# Altium schematic sheet Relays.SchDoc
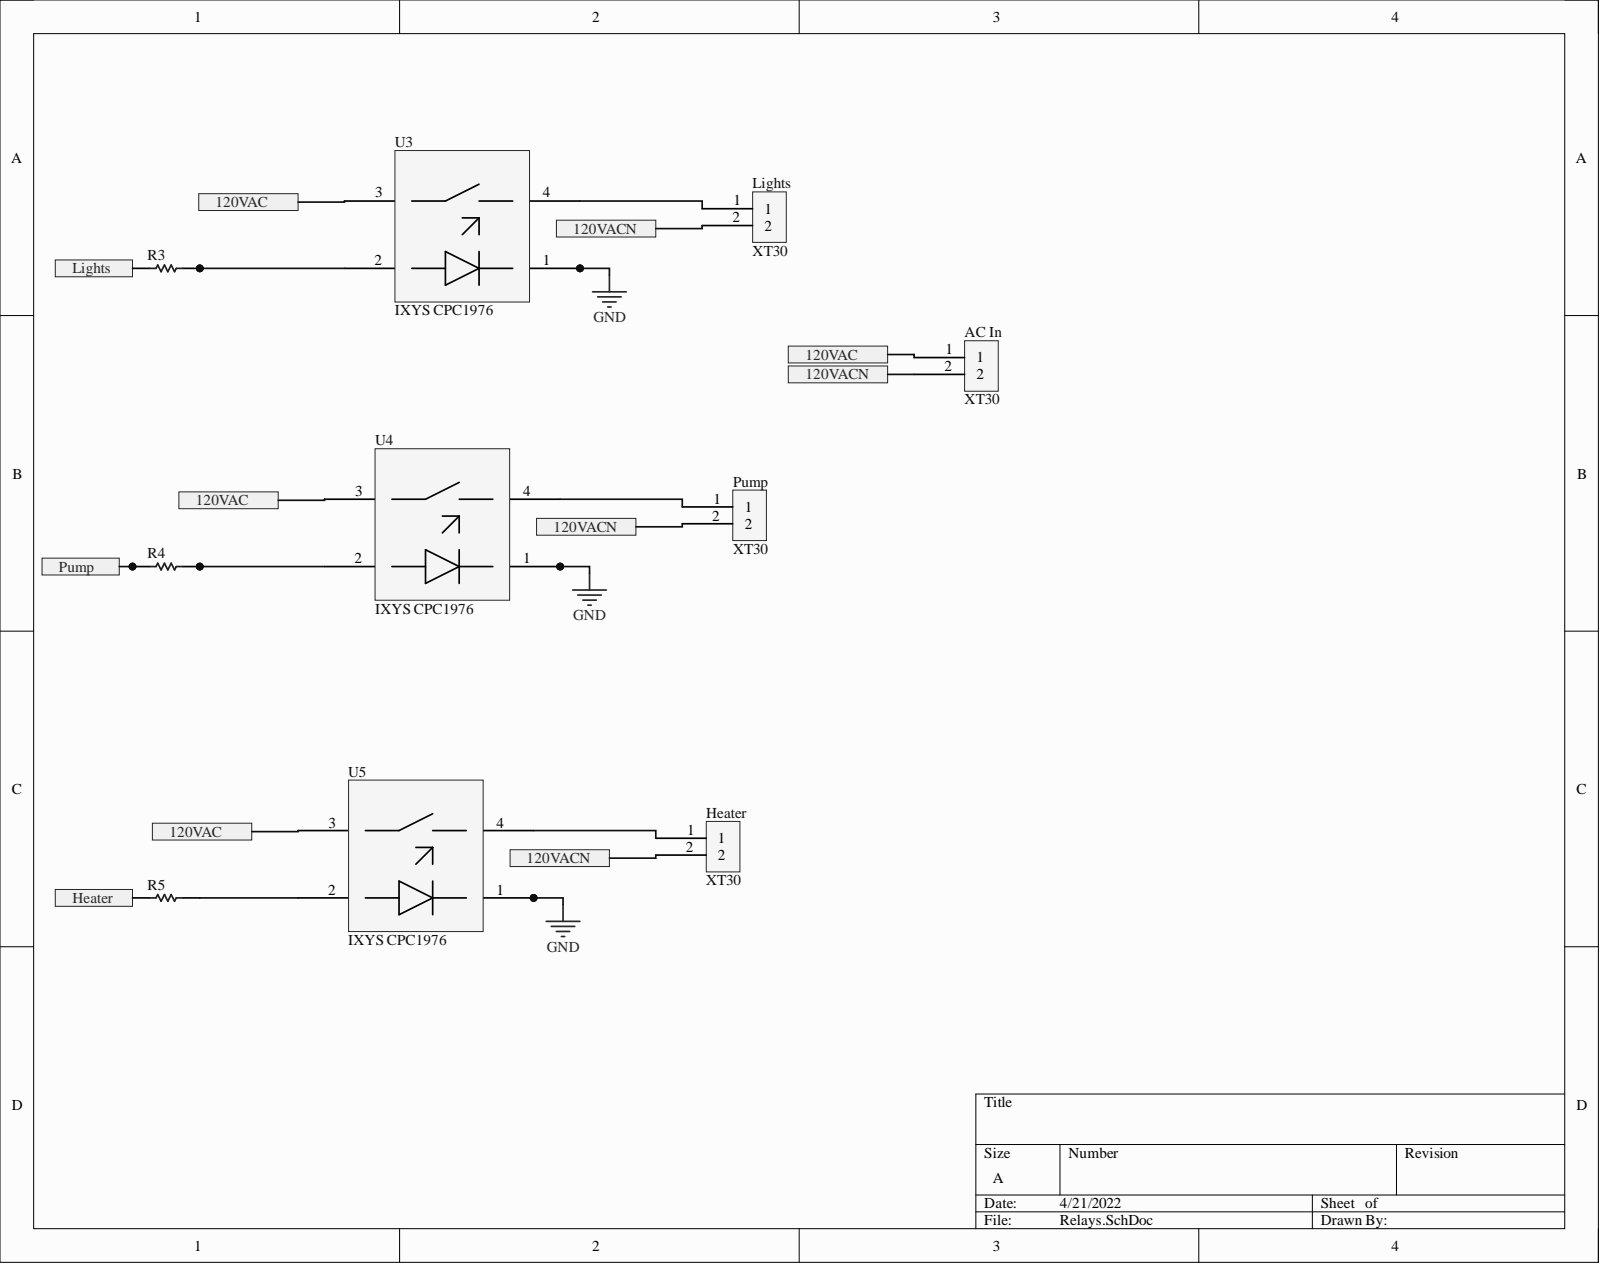
[diagram p0: Relays.SchDoc]

## Parts
AC_In  XT30  [PCB-8h6goupi0sbkduz6cbnu-1]
Heater  XT30  [PCB-8h6goupi0sbkduz6cbnu-1]
Lights  XT30  [PCB-8h6goupi0sbkduz6cbnu-1]
Pump  XT30  [PCB-8h6goupi0sbkduz6cbnu-1]
R3  YAGEO RC0805JR-072KL  [PCB-tn648mo2y0oen0ikj7ny-1]  (value not drawn)
R4  YAGEO RC0805JR-072KL  [PCB-tn648mo2y0oen0ikj7ny-1]  (value not drawn)
R5  YAGEO RC0805JR-072KL  [PCB-tn648mo2y0oen0ikj7ny-1]  (value not drawn)
U3  IXYS CPC1976  [PCB-zafdc8d7yo8tvu9datrn-1]
U4  IXYS CPC1976  [PCB-zafdc8d7yo8tvu9datrn-1]
U5  IXYS CPC1976  [PCB-zafdc8d7yo8tvu9datrn-1]

## Ports
120VAC, 120VACN, Heater, Lights, Pump

## Nets
GND: U3.1 U4.1 U5.1
120VAC: AC_In.1 U3.3 U4.3 U5.3 ; port 120VAC
120VACN: AC_In.2 Heater.2 Lights.2 Pump.2 ; port 120VACN
Heater: R5.1 ; port Heater
Lights: R3.1 ; port Lights
Pump: R4.1 ; port Pump
NetHeater_1: Heater.1 U5.4
NetLights_1: Lights.1 U3.4
NetPump_1: Pump.1 U4.4
NetR3_2: R3.2 U3.2
NetR4_2: R4.2 U4.2
NetR5_2: R5.2 U5.2

## Library data of the parts
AC_In Heater Lights Pump = CMP-nlx8yci0umf6n9sk0ty3-5: Very similar to the XT60 models, but smaller and made for 30A; Component Kind: Standard; CustomComponentID: nlx8yci0umf6n9sk0ty3; Homepage URL: https://www.amazon.com/ProgressiveRC-AC-XT30-XT30-Connectors-pairs/dp/B011WDZGM0; Library Name: FavoritesLibrary
R3-R5 = CMP-1854011-4: RC Series 0805 0.125 W 2 kOhms 5% ±100 ppm/°C SMT Thick Film Chip Resistor; Case/Package (SI): 2012; Lead-Free Status: Lead Free; Power Rating: 0.125 W; Resistance: 10k; Voltage Rating (DC): 150.0 V; Case/Package: 2012 SI; Component Kind: Standard; Library Name: My Personal Space; Mounting Style: Surface Mount; Number of Pins: 2; Octopart Url: http://octopart.com/rc0805jr-072kl-yageo-1251295; OctopartID: 57629e7b65db88a5; Resistance Tolerance: ±5%; RoHS: Compliant; Seller Landing  Url: https://octopart-clicks.com/click/track?country=US&ak=8157f166&sig=0739e0e&sid=2402&ppid=1251295&vpid=121062726&ct=offers; Size-Height: 0.0005 m; Size-Length: 0.002 m; Size-Width: 0.00125 m
U3-U5 = CMP-ec8224d1dac13345-3: CPC1972 Series 2 A 600 V Solid State Relay Through Hole - SIP4; Component Kind: Standard; Library Name: My Personal Space
